# Altium schematic sheet Page5_GPS.SchDoc
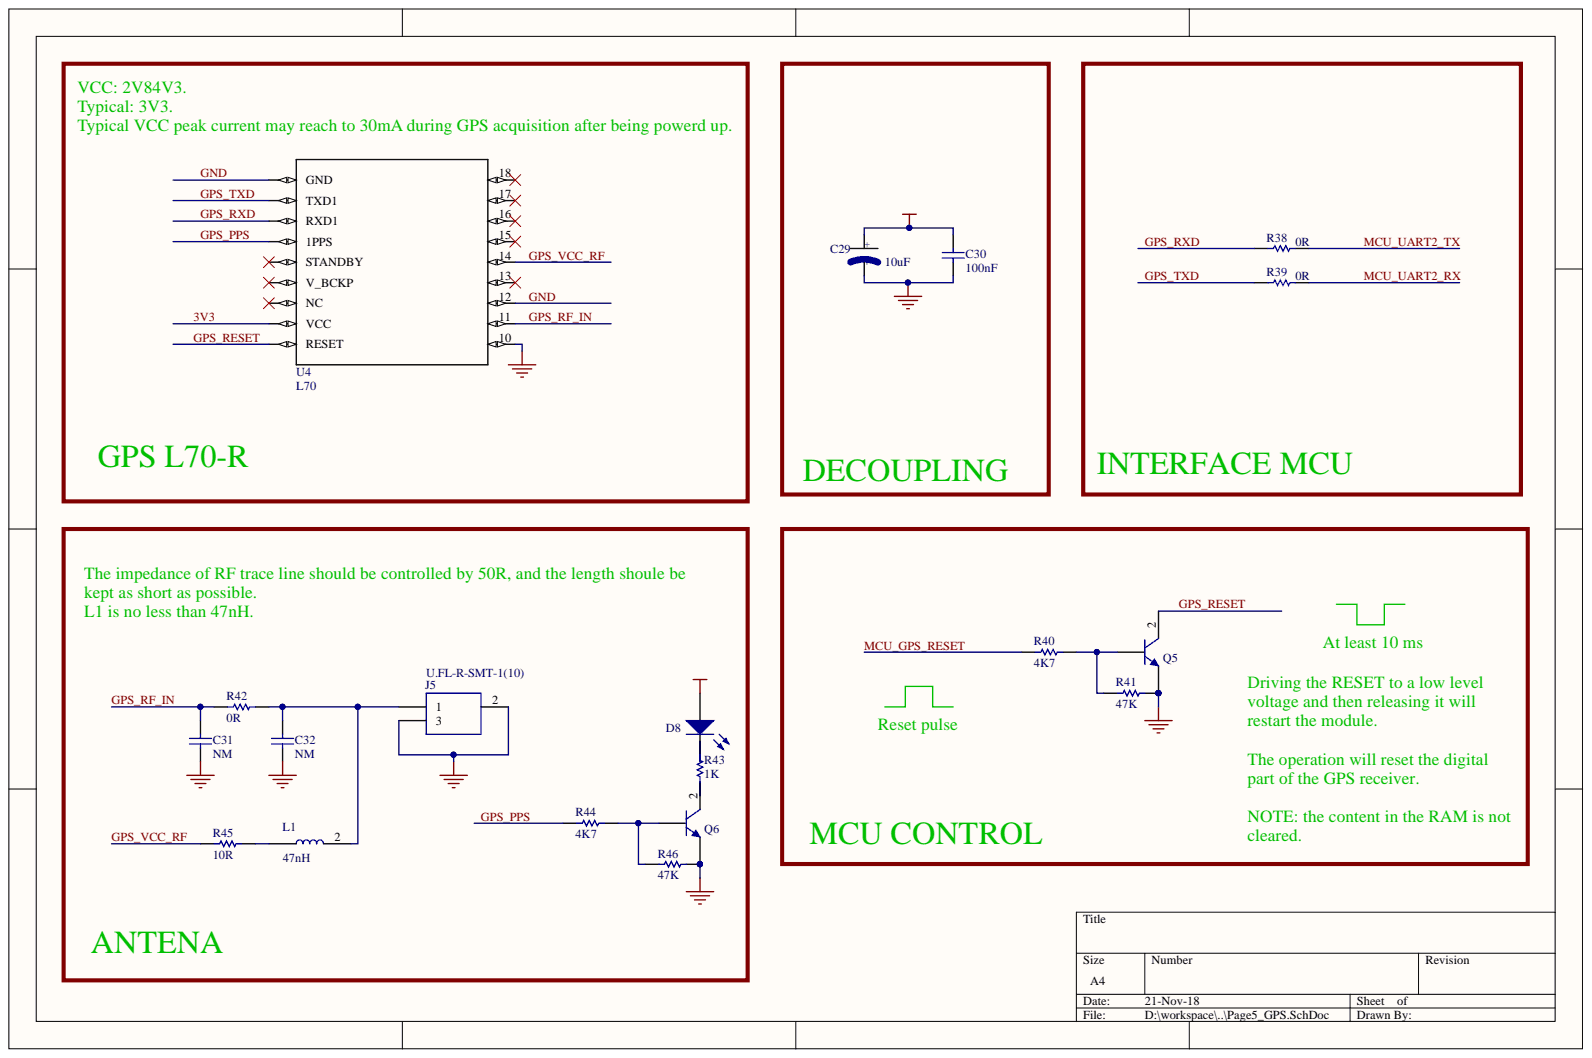
[diagram p0: Page5_GPS.SchDoc]

## Parts
C29  10uF  [AVX-A_TANTALUM-SMD-10UF-10V-10%(AVX-A)(Primary)]
C30  value 100nF  [CAP-SM-0603]  (hidden comment: Cap Non-Pol SMD)
C31  value NM  [CAP-SM-0603]  (hidden comment: Cap Non-Pol SMD)
C32  value NM  [CAP-SM-0603]  (hidden comment: Cap Non-Pol SMD)
D8  LED SMD  [LED_0603_Blue]  (value not drawn)
J5  U.FL-R-SMT-1(10)  [U.FL-R-SMT-1(10)]
L1  47nH  [INDC2012X87N]
Q5  C1815  [SOT23B]  (value not drawn)
Q6  C1815  [SOT23B]  (value not drawn)
R38  value 0R  [RES-SM-0603]  (hidden comment: RESISTOR SMD)
R39  value 0R  [RES-SM-0603]  (hidden comment: RESISTOR SMD)
R40  value 4K7  [RES-SM-0603]  (hidden comment: RESISTOR SMD)
R41  value 47K  [RES-SM-0603]  (hidden comment: RESISTOR SMD)
R42  value 0R  [RES-SM-0603]  (hidden comment: RESISTOR SMD)
R43  value 1K  [RES-SM-0603]  (hidden comment: RESISTOR SMD)
R44  value 4K7  [RES-SM-0603]  (hidden comment: RESISTOR SMD)
R45  value 10R  [RES-SM-0603]  (hidden comment: RESISTOR SMD)
R46  value 47K  [RES-SM-0603]  (hidden comment: RESISTOR SMD)
U4  L70  [MOD18]

## Nets
3V3: C29.POS C30.2 D8.1 U4.8(VCC)
GND: C29.NEG C30.1 C31.1 C32.1 J5.2 J5.3 Q5.1 Q6.1 R41.2 R46.2 U4.1(GND) U4.10 U4.12
GPS_PPS: R44.1 U4.4(1PPS)
GPS_RESET: Q5.2 U4.9(RESET)
GPS_RF_IN: C31.2 R42.1 U4.11
GPS_RXD: R38.1 U4.3(RXD1)
GPS_TXD: R39.1 U4.2(TXD1)
GPS_VCC_RF: R45.1 U4.14
MCU_GPS_RESET: R40.1
MCU_UART2_RX: R39.2
MCU_UART2_TX: R38.2
NetC32_2: C32.2 J5.1 L1.2 R42.2
NetD8_2: D8.2 R43.2
NetL1_1: L1.1 R45.2
NetQ5_3: Q5.3 R40.2 R41.1
NetQ6_2: Q6.2 R43.1
NetQ6_3: Q6.3 R44.2 R46.1

Not connected: U4 5(STANDBY) 6(V_BCKP) 7(NC) 13 15 16 17 18

## Library data of the parts
C29 = TANTALUM-SMD-10UF-10V-10%(AVX-A): Cap Tant Solid 10uF 10V A CASE 10% _3.2 X 1.6 X 1.6mm_ SMD 3216-18 3 Ohm 125Â°C T/R; MF: AVX Interconnect / Elco; MP: TAJA106K010RNJ; Availability: Unavailable; Component Kind: Standard; Library Name: TANTALUM-SMD-10UF-10V-10__AVX-A_.SchLib; Package: 1206 AVX Interconnect / Elco; Price: None; SnapEDA_Link: https://www.snapeda.com/parts/TAJA106K010RNJ/AVX%20Interconnect%20/%20Elco/view-part/?ref=snap
C30-C32 = Cap Non-Pol SMD: Capacitor; Component Kind: Standard; Library Name: PIF_Library.IntLib
D8 = LED SMD: LED; Component Kind: Standard; Library Name: PIF_Library.IntLib
J5 = U.FL-R-SMT-1(10): UFL SMT PCB receptable,DC-6GHz Hirose Straight 50 Surface Mount UFL Connector, Receptacle, Solder Termination Coaxial; Arrow Part Number: U.FL-R-SMT-1(10); Arrow Price/Stock: https://www.arrow.com/en/products/u.fl-r-smt-1-10/hirose-electric; Component Kind: Standard; Datasheet Link: https://www.hirose.com/product/en/download_file/key_name/U.FL-R-SMT-1%2810%29/category/Drawing%20(2D)/doc_file_id/37627/?file_category_id=6&item_id=03310472210&is_series=; Library Name: U.FL-R-SMT-1(10).SchLib; Manufacturer_Name: Hirose; Manufacturer_Part_Number: U.FL-R-SMT-1(10); RS Part Number: 1757510; RS Price/Stock: http://uk.rs-online.com/web/p/products/1757510
L1 = MLZ2012M4R7WT000: Surface Mount High Frequency Inductor, MLZ Series, 4.7 H, 20%, 0805 [2012 Metric], 50 MHz; MF: TDK; Availability: Unavailable; Component Kind: Standard; Library Name: MLZ2012M4R7WT000.SchLib; MP: MLZ2012M4R7WT000; Package: 0805 TDK; Price: None; SnapEDA_Link: https://www.snapeda.com/parts/MLZ2012M4R7WT000/TDK-Lambda%20Americas%20Inc/view-part/?ref=snap
Q5 Q6 = C1815: NPN Bipolar Transistor; Component Kind: Standard; Library Name: PIF_Library.IntLib
R38-R46 = RESISTOR SMD: Resistor; Component Kind: Standard; Library Name: PIF_Library.IntLib
U4 = L70: Gps Module + Mt3339 + 9600bps; MF: Quectel; Availability: Unavailable; Component Kind: Standard; Library Name: L70.SchLib; MP: L70; Package: None; Price: None; SnapEDA_Link: https://www.snapeda.com/parts/L70/Quectel/view-part/?ref=snap
